# Altium schematic sheet AD936X_Digital.SchDoc(AD936X_Digital)
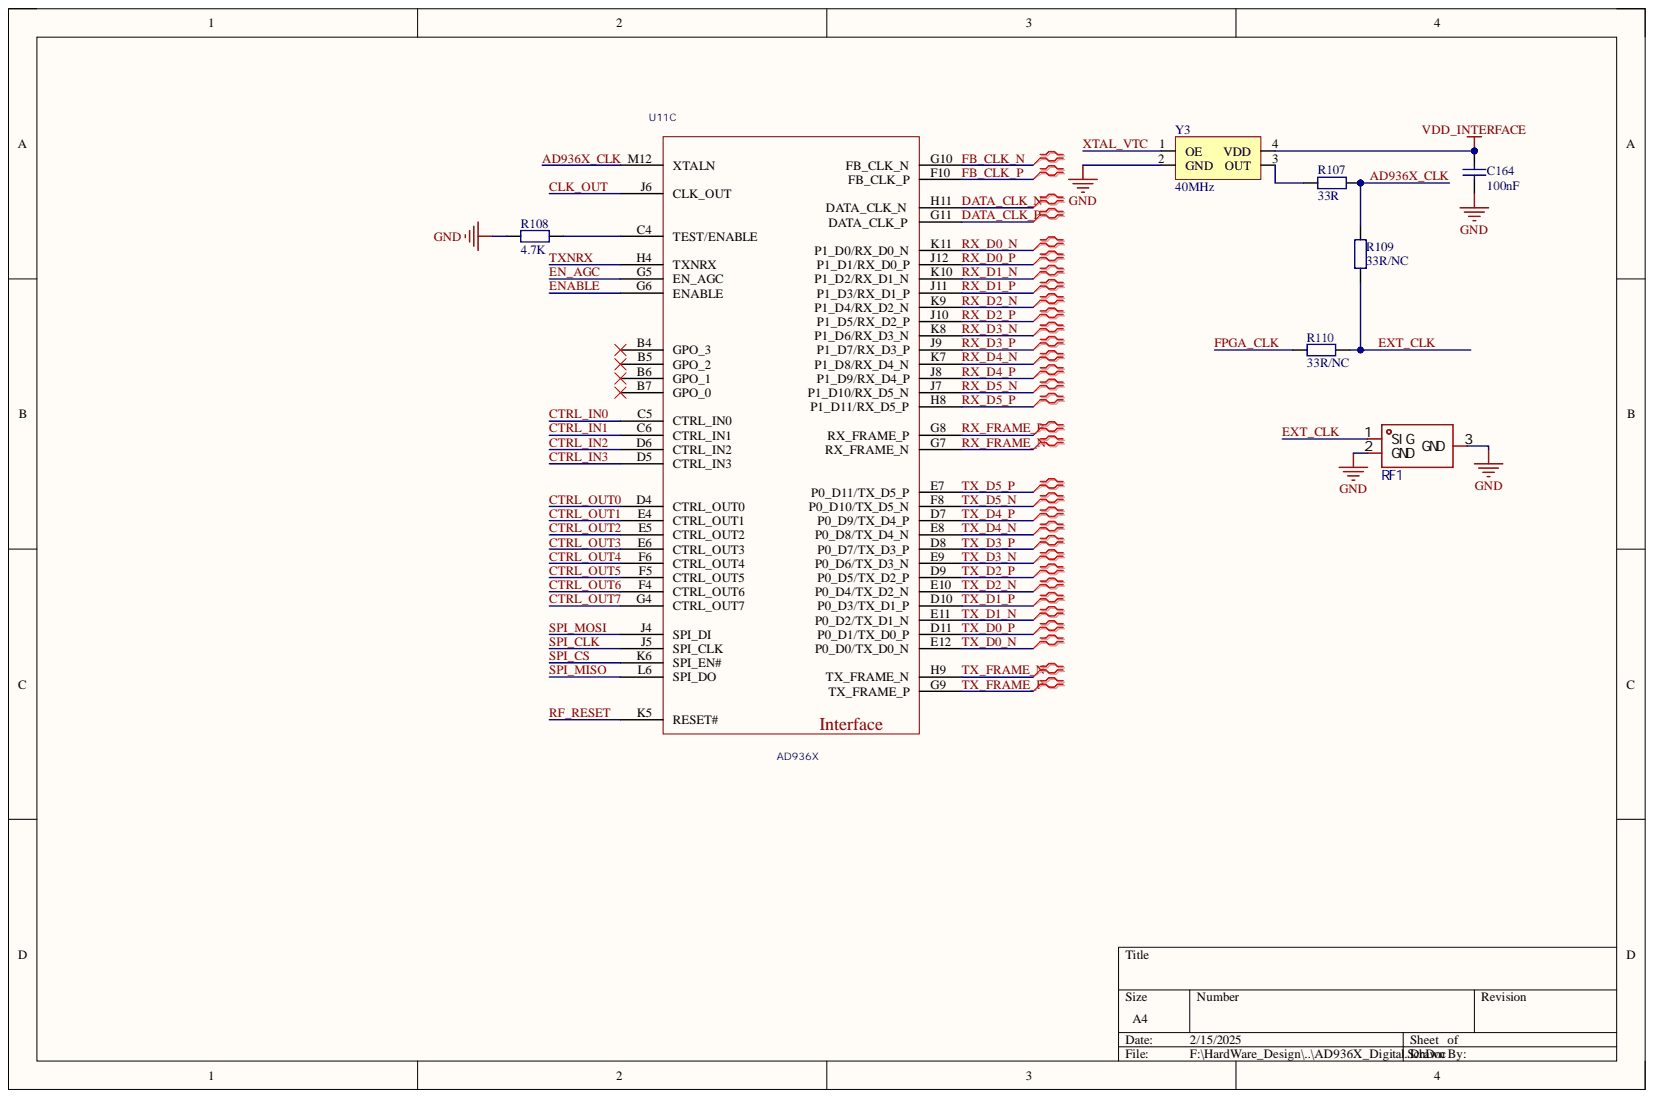
[diagram p0: AD936X_Digital.SchDoc]

## Parts
C164  100nF  [C 0402_L]
R107  33R  [R 0402_L]
R108  4.7K  [R 0402_L]
R109  33R/NC  [R 0402_L]
R110  33R/NC  [R 0402_L]
RF1  BWIPX-1-001E  [IPEX-SMD_BWIPX-1-001E]  (value not drawn)
U11  AD936X  [BGA144C80P12X12_1000X1000X170]  (part C)
Y3  40M  value 40MHz  [OSC 3225-4P]

## Nets
GND: C164.1 R108.1 RF1.2 RF1.3 Y3.2(GND)
VDD_INTERFACE: C164.2 Y3.4(VDD)
AD936X_CLK: R107.2 R109.2 U11.M12(XTALN)
CLK_OUT: U11.J6(CLK_OUT)
CTRL_IN0: U11.C5(CTRL_IN0)
CTRL_IN1: U11.C6(CTRL_IN1)
CTRL_IN2: U11.D6(CTRL_IN2)
CTRL_IN3: U11.D5(CTRL_IN3)
CTRL_OUT0: U11.D4(CTRL_OUT0)
CTRL_OUT1: U11.E4(CTRL_OUT1)
CTRL_OUT2: U11.E5(CTRL_OUT2)
CTRL_OUT3: U11.E6(CTRL_OUT3)
CTRL_OUT4: U11.F6(CTRL_OUT4)
CTRL_OUT5: U11.F5(CTRL_OUT5)
CTRL_OUT6: U11.F4(CTRL_OUT6)
CTRL_OUT7: U11.G4(CTRL_OUT7)
DATA_CLK_N: U11.H11(DATA_CLK_N)
DATA_CLK_P: U11.G11(DATA_CLK_P)
EN_AGC: U11.G5(EN_AGC)
ENABLE: U11.G6(ENABLE)
EXT_CLK: R109.1 R110.1 RF1.1
FB_CLK_N: U11.G10(FB_CLK_N)
FB_CLK_P: U11.F10(FB_CLK_P)
FPGA_CLK: R110.2
RF_RESET: U11.K5(RESET#)
RX_D0_N: U11.K11(P1_D0/RX_D0_N)
RX_D0_P: U11.J12(P1_D1/RX_D0_P)
RX_D1_N: U11.K10(P1_D2/RX_D1_N)
RX_D1_P: U11.J11(P1_D3/RX_D1_P)
RX_D2_N: U11.K9(P1_D4/RX_D2_N)
RX_D2_P: U11.J10(P1_D5/RX_D2_P)
RX_D3_N: U11.K8(P1_D6/RX_D3_N)
RX_D3_P: U11.J9(P1_D7/RX_D3_P)
RX_D4_N: U11.K7(P1_D8/RX_D4_N)
RX_D4_P: U11.J8(P1_D9/RX_D4_P)
RX_D5_N: U11.J7(P1_D10/RX_D5_N)
RX_D5_P: U11.H8(P1_D11/RX_D5_P)
RX_FRAME_N: U11.G7(RX_FRAME_N)
RX_FRAME_P: U11.G8(RX_FRAME_P)
SPI_CLK: U11.J5(SPI_CLK)
SPI_CS: U11.K6(SPI_EN#)
SPI_MISO: U11.L6(SPI_DO)
SPI_MOSI: U11.J4(SPI_DI)
TX_D0_N: U11.E12(P0_D0/TX_D0_N)
TX_D0_P: U11.D11(P0_D1/TX_D0_P)
TX_D1_N: U11.E11(P0_D2/TX_D1_N)
TX_D1_P: U11.D10(P0_D3/TX_D1_P)
TX_D2_N: U11.E10(P0_D4/TX_D2_N)
TX_D2_P: U11.D9(P0_D5/TX_D2_P)
TX_D3_N: U11.E9(P0_D6/TX_D3_N)
TX_D3_P: U11.D8(P0_D7/TX_D3_P)
TX_D4_N: U11.E8(P0_D8/TX_D4_N)
TX_D4_P: U11.D7(P0_D9/TX_D4_P)
TX_D5_N: U11.F8(P0_D10/TX_D5_N)
TX_D5_P: U11.E7(P0_D11/TX_D5_P)
TX_FRAME_N: U11.H9(TX_FRAME_N)
TX_FRAME_P: U11.G9(TX_FRAME_P)
TXNRX: U11.H4(TXNRX)
XTAL_VTC: Y3.1(OE)
NetR107_1: R107.1 Y3.3(OUT)
NetR108_2: R108.2 U11.C4(TEST/ENABLE)

Not connected: U11 B4(GPO_3) B5(GPO_2) B6(GPO_1) B7(GPO_0)

## Library data of the parts
C164 = Cap: 贴片电容; Component Kind: Standard; Library Name: A_Schlib.SchLib; value: 100nF
R107-R110 = Res: 通用; Component Kind: Standard; Library Name: A_Schlib.SchLib
  R107: value: 33R
  R108: value: 4.7K
  R109 R110: value: 33R/NC
RF1 = BWIPX-1-001E: 连接器类型:板端;接口类型:内针;端口数量:1;接口直径:-;接口长度/高度:-;频率-最大值:6GHz;射频系列:IPEX;阻抗:50Ω;样式:-;工作温度范围:-;; Manufacturer: BAT WIRELESS(蝙蝠无线); Add into BOM: yes; Connector Type: 板端; Frequency-Max: 6GHz; Impedance: 50Ω; Interface Type: 内针; Number of Ports: 1; RF Series: IPEX; Component Kind: Standard; Convert to PCB: yes; Datasheet: https://atta.szlcsc.com/upload/public/pdf/source/20201223/C496552_05E5A9956EAE1A8819C5051D085B1DED.pdf; Device: BWIPX-1-001E; Interface Diameter: -; Interface Length/Height: -; JLCPCB Part Class: 扩展库; LCSC Part Name: IPEX 内针; Library Name: A_Schlib.SchLib; Manufacturer Part: BWIPX-1-001E; Operating Temperature Range: -; Style: -; Supplier: LCSC; Supplier Footprint: SMD; Supplier Part: C496552; Symbol: BWIPX-1-001E
U11 = AD936X: 射频捷变收发器; Component Kind: Standard; Library Name: A_Schlib.SchLib
Y3 = XATLS-4P: 4脚贴片有源晶振; Component Kind: Standard; Library Name: A_Schlib.SchLib; 封装库来自淘宝卖家 ID：黄晶宝石: https://shop463601444.taobao.com
